# Altium schematic sheet impee-mailbox.SchDoc
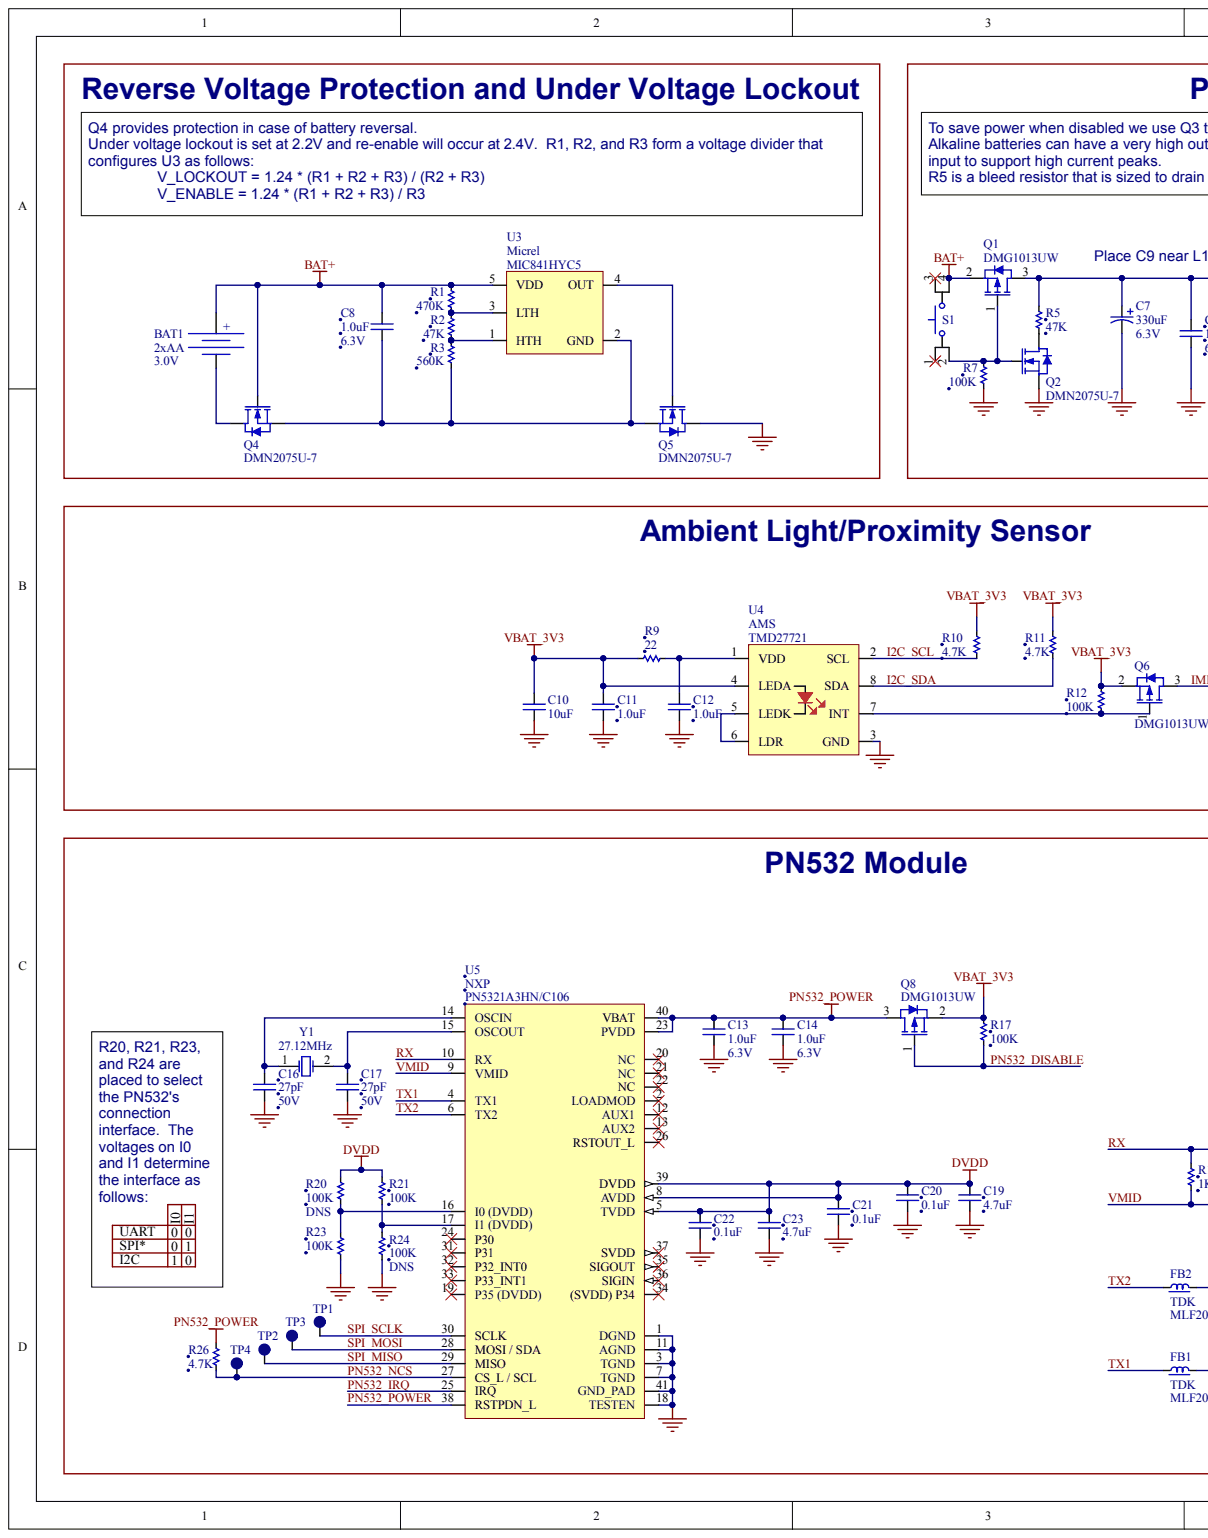
[diagram p0: impee-mailbox.SchDoc, left]
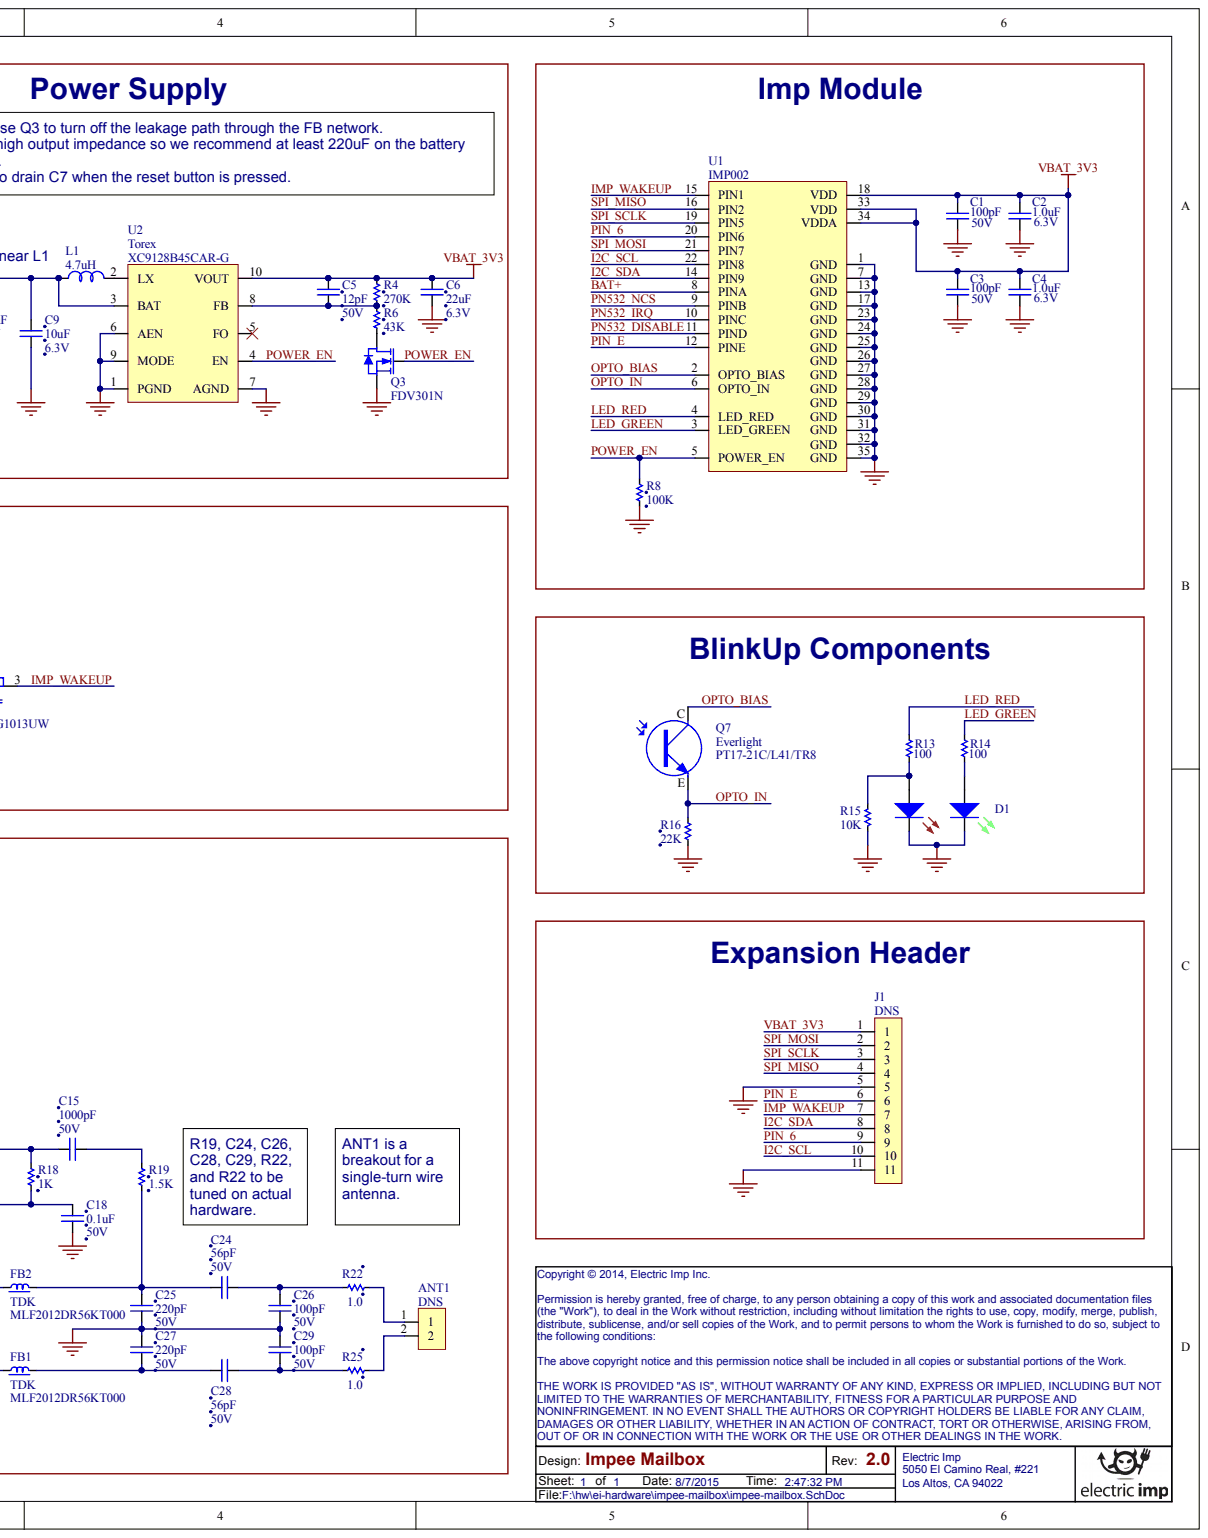
[diagram p1: impee-mailbox.SchDoc, right]

## Parts
ANT1  BOMOPTION: DNS  [HDR254P1X2-N]  (hidden comment: Header)  (no BOM)
BAT1  Configuration: 2xAA  Voltage: 3.0V  [12BH321P-GR]  (hidden comment: BATTERY)
C1  value 100pF  Voltage: 50V  [CAPC1005X07N]  (hidden comment: Ceramic Capacitor)
C2  value 1.0uF  Voltage: 6.3V  [CAPC1005X07N]  (hidden comment: Ceramic Capacitor)
C3  value 100pF  Voltage: 50V  [CAPC1005X07N]  (hidden comment: Ceramic Capacitor)
C4  value 1.0uF  Voltage: 6.3V  [CAPC1005X07N]  (hidden comment: Ceramic Capacitor)
C5  value 12pF  Voltage: 50V  [CAPC1005X07N]  (hidden comment: Ceramic Capacitor)
C6  value 22uF  Voltage: 6.3V  [CAPC2012X14N]  (hidden comment: Ceramic Capacitor)
C7  value 330uF  Voltage: 6.3V  [CAPAE8983X55N]  (hidden comment: Polarized Capacitor)
C8  value 1.0uF  Voltage: 6.3V  [CAPC1005X07N]  (hidden comment: Ceramic Capacitor)
C9  value 10uF  Voltage: 6.3V  [CAPC2012X14N]  (hidden comment: Ceramic Capacitor)
C10  value 10uF  [CAPC2012X14N]  (hidden comment: Ceramic Capacitor)
C11  value 1.0uF  [CAPC1005X07N]  (hidden comment: Ceramic Capacitor)
C12  value 1.0uF  [CAPC1005X07N]  (hidden comment: Ceramic Capacitor)
C13  value 1.0uF  Voltage: 6.3V  [CAPC1005X07N]  (hidden comment: Ceramic Capacitor)
C14  value 1.0uF  Voltage: 6.3V  [CAPC1005X07N]  (hidden comment: Ceramic Capacitor)
C15  value 1000pF  Voltage: 50V  [CAPC1005X07N]  (hidden comment: Ceramic Capacitor)
C16  value 27pF  Voltage: 50V  [CAPC1005X07N]  (hidden comment: Ceramic Capacitor)
C17  value 27pF  Voltage: 50V  [CAPC1005X07N]  (hidden comment: Ceramic Capacitor)
C18  value 0.1uF  Voltage: 50V  [CAPC2012X14N]  (hidden comment: Ceramic Capacitor)
C19  value 4.7uF  [CAPC1608X10N]  (hidden comment: Ceramic Capacitor)
C20  value 0.1uF  [CAPC1005X07N]  (hidden comment: Ceramic Capacitor)
C21  value 0.1uF  [CAPC1005X07N]  (hidden comment: Ceramic Capacitor)
C22  value 0.1uF  [CAPC1005X07N]  (hidden comment: Ceramic Capacitor)
C23  value 4.7uF  [CAPC1608X10N]  (hidden comment: Ceramic Capacitor)
C24  value 56pF  Voltage: 50V  [CAPC1005X07N]  (hidden comment: Ceramic Capacitor)
C25  value 220pF  Voltage: 50V  [CAPC1005X07N]  (hidden comment: Ceramic Capacitor)
C26  value 100pF  Voltage: 50V  [CAPC1005X07N]  (hidden comment: Ceramic Capacitor)
C27  value 220pF  Voltage: 50V  [CAPC1005X07N]  (hidden comment: Ceramic Capacitor)
C28  value 56pF  Voltage: 50V  [CAPC1005X07N]  (hidden comment: Ceramic Capacitor)
C29  value 100pF  Voltage: 50V  [CAPC1005X07N]  (hidden comment: Ceramic Capacitor)
D1  LED Red and Green  [LED1616X07N-REDGREEN]  (value not drawn)
FB1  Manufacturer: TDK  Manufacturer Part Number: MLF2012DR56KT000  [INDC2012X11N]  (hidden comment: Ferrite Bead)
FB2  Manufacturer: TDK  [INDC2012X11N]  (hidden comment: Ferrite Bead)
J1  Header  BOMOPTION: DNS  [HDR254P1X11-N]  (no BOM)
L1  value 4.7uH  [INDP6060X28N]  (hidden comment: Inductor)
Q1  Manufacturer Part Number: DMG1013UW  [SOT65P210X110-3N]  (hidden comment: PFET)
Q2  Manufacturer Part Number: DMN2075U-7  [SOT95P300X130-3N]  (hidden comment: N-Channel MOSFET)
Q3  Manufacturer Part Number: FDV301N  [SOT95P300X130-3N]  (hidden comment: N-Channel MOSFET)
Q4  Manufacturer Part Number: DMN2075U-7  [SOT95P300X130-3N]  (hidden comment: N-Channel MOSFET)
Q5  Manufacturer Part Number: DMN2075U-7  [SOT95P300X130-3N]  (hidden comment: N-Channel MOSFET)
Q6  Manufacturer Part Number: DMG1013UW  [SOT65P210X110-3N]  (hidden comment: PFET)
Q7  Manufacturer: Everlight  Manufacturer Part Number: PT17-21C/L41/TR8  [PHOTOTRANS2012X13]  (hidden comment: PHOTOTRANSISTOR)
Q8  Manufacturer Part Number: DMG1013UW  [SOT65P210X110-3N]  (hidden comment: PFET)
R1  value 470K  [RESC1005X04N]  (hidden comment: Resistor)
R2  value 47K  [RESC1005X04N]  (hidden comment: Resistor)
R3  value 560K  [RESC1005X04N]  (hidden comment: Resistor)
R4  value 270K  [RESC1005X04N]  (hidden comment: Resistor)
R5  value 47K  [RESC1005X04N]  (hidden comment: Resistor)
R6  value 43K  [RESC1005X04N]  (hidden comment: Resistor)
R7  value 100K  [RESC1005X04N]  (hidden comment: Resistor)
R8  value 100K  [RESC1005X04N]  (hidden comment: Resistor)
R9  value 22  [RESC1005X04N]  (hidden comment: Resistor)
R10  value 4.7K  [RESC1005X04N]  (hidden comment: Resistor)
R11  value 4.7K  [RESC1005X04N]  (hidden comment: Resistor)
R12  value 100K  [RESC1005X04N]  (hidden comment: Resistor)
R13  value 100  [RESC1005X04N]  (hidden comment: Resistor)
R14  value 100  [RESC1005X04N]  (hidden comment: Resistor)
R15  value 10K  [RESC1005X04N]  (hidden comment: Resistor)
R16  value 22K  [RESC1005X04N]  (hidden comment: Resistor)
R17  value 100K  [RESC1005X04N]  (hidden comment: Resistor)
R18  value 1K  [RESC1005X04N]  (hidden comment: Resistor)
R19  value 1.5K  [RESC1005X04N]  (hidden comment: Resistor)
R20  value 100K  BOMOPTION: DNS  [RESC1005X04N]  (hidden comment: Resistor)  (no BOM)
R21  value 100K  [RESC1005X04N]  (hidden comment: Resistor)
R22  value 1.0  [RESC2012X07N]  (hidden comment: Resistor)
R23  value 100K  [RESC1005X04N]  (hidden comment: Resistor)
R24  value 100K  BOMOPTION: DNS  [RESC1005X04N]  (hidden comment: Resistor)  (no BOM)
R25  value 1.0  [RESC2012X07N]  (hidden comment: Resistor)
R26  value 4.7K  [RESC1005X04N]  (hidden comment: Resistor)
S1  Push Button  [BTN600X600X430-4N]  (value not drawn)
TP1  Testpoint  [TP254P1X1-TH]  (value not drawn)  (no BOM)
TP2  Testpoint  [TP254P1X1-TH]  (value not drawn)  (no BOM)
TP3  Testpoint  [TP254P1X1-TH]  (value not drawn)  (no BOM)
TP4  Testpoint  [TP254P1X1-TH]  (value not drawn)  (no BOM)
U1  Manufacturer Part Number: IMP002  [IMP_MODULE]  (hidden comment: imp module)
U2  XC9128  Manufacturer: Torex  Manufacturer Part Number: XC9128B45CAR-G  [MSOP50P490X116-10N]
U3  MIC841  Manufacturer: Micrel  Manufacturer Part Number: MIC841HYC5  [SOT65P200X125-5N]
U4  TMD2772  Manufacturer: AMS  Manufacturer Part Number: TMD27721  [SON97P240X155-8N]
U5  PN532  Manufacturer: NXP  Manufacturer Part Number: PN5321A3HN/C106  [QFN50P600X600X100_HS-41N]
Y1  Frequency: 27.12MHz  [XTAL5032X135]  (hidden comment: XTAL)

## Nets
DVDD: C19.2 C20.2 C21.2 C22.2 C23.2 R20.1 R21.1 U5.5(TVDD) U5.8(AVDD) U5.39(DVDD)
GND: C1.1 C2.1 C3.1 C4.1 C6.1 C7.2 C9.1 C10.1 C11.1 C12.1 C13.1 C14.1 C16.1 C17.1 C18.1 C19.1 C20.1 C21.1 C22.1 C23.1 C25.1 C26.1 C27.2 C29.2 D1.KG D1.KR J1.5 J1.11 Q2.2 Q3.2 Q5.3 R7.2 R8.2 R15.1 R16.2 R23.2 R24.2 U1.1(GND) U1.7(GND) U1.13(GND) U1.17(GND) U1.23(GND) U1.24(GND) U1.25(GND) U1.26(GND) U1.27(GND) U1.28(GND) U1.29(GND) U1.30(GND) U1.31(GND) U1.32(GND) U1.35(GND) U2.1(PGND) U2.6(AEN) U2.7(AGND) U2.9(MODE) U4.3(GND) U5.1(DGND) U5.3(TGND) U5.7(TGND) U5.11(AGND) U5.18(TESTEN) U5.41(GND_PAD)
BAT+: BAT1.+ C8.2 Q1.2 Q4.1 R1.1 S1.4 U1.8(PINA) U3.5(VDD)
I2C_SCL: J1.10 R10.2 U1.22(PIN8) U4.2(SCL)
I2C_SDA: J1.8 R11.2 U1.14(PIN9) U4.8(SDA)
IMP_WAKEUP: J1.7 Q6.3 U1.15(PIN1)
LED_GREEN: R14.1 U1.3(LED_GREEN)
LED_RED: R13.1 U1.4(LED_RED)
OPTO_BIAS: Q7.C U1.2(OPTO_BIAS)
OPTO_IN: Q7.E R16.1 U1.6(OPTO_IN)
PIN_6: J1.9 U1.20(PIN6)
PIN_E: J1.6 U1.12(PINE)
PN532_DISABLE: Q8.1 R17.2 U1.11(PIND)
PN532_IRQ: U1.10(PINC) U5.25(IRQ)
PN532_NCS: R26.2 TP4.1 U1.9(PINB) U5.27(CS_L_/_SCL)
PN532_POWER: C13.2 C14.2 Q8.3 R26.1 U5.23(PVDD) U5.38(RSTPDN_L) U5.40(VBAT)
POWER_EN: Q3.1 R8.1 U1.5(POWER_EN) U2.4(EN)
RX: C15.1 R18.1 U5.10(RX)
SPI_MISO: J1.4 TP2.1 U1.16(PIN2) U5.29(MISO)
SPI_MOSI: J1.2 TP3.1 U1.21(PIN7) U5.28(MOSI_/_SDA)
SPI_SCLK: J1.3 TP1.1 U1.19(PIN5) U5.30(SCLK)
TX1: FB1.1 U5.4(TX1)
TX2: FB2.1 U5.6(TX2)
VBAT_3V3: C1.2 C2.2 C3.2 C4.2 C5.2 C6.2 C10.2 C11.2 J1.1 Q6.2 Q8.2 R4.1 R9.2 R10.1 R11.1 R12.1 R17.1 U1.18(VDD) U1.33(VDD) U1.34(VDDA) U2.10(VOUT) U4.4(LEDA)
VMID: C18.2 R18.2 U5.9(VMID)
NetANT1_1: ANT1.1 R22.2
NetANT1_2: ANT1.2 R25.2
NetBAT1_-: BAT1.- Q4.3
NetC5_1: C5.1 R4.2 R6.1 U2.8(FB)
NetC7_1: C7.1 C9.2 L1.1 Q1.3 R5.1 U2.3(BAT)
NetC8_1: C8.1 Q4.2 Q5.2 R3.2 U3.2(GND)
NetC12_2: C12.2 R9.1 U4.1(VDD)
NetC15_2: C15.2 R19.1
NetC16_2: C16.2 U5.14(OSCIN) Y1.1
NetC17_2: C17.2 U5.15(OSCOUT) Y1.2
NetC24_1: C24.1 C25.2 FB2.2 R19.2
NetC24_2: C24.2 C26.2 R22.1
NetC27_1: C27.1 C28.1 FB1.2
NetC28_2: C28.2 C29.1 R25.1
NetD1_AG: D1.AG R14.2
NetD1_AR: D1.AR R13.2 R15.2
NetL1_2: L1.2 U2.2(LX)
NetQ1_1: Q1.1 Q2.1 R7.1 S1.2
NetQ2_3: Q2.3 R5.2
NetQ3_3: Q3.3 R6.2
NetQ5_1: Q5.1 U3.4(OUT)
NetQ6_1: Q6.1 R12.2 U4.7(INT)
NetR1_2: R1.2 R2.1 U3.3(LTH)
NetR2_2: R2.2 R3.1 U3.1(HTH)
NetR20_2: R20.2 R23.1 U5.16(I0_(DVDD))
NetR21_2: R21.2 R24.1 U5.17(I1_(DVDD))
NetU4_5: U4.5(LEDK) U4.6(LDR)

Not connected: S1 1 3; U2 5(FO); U5 2(LOADMOD) 12(AUX1) 13(AUX2) 19(P35_(DVDD)) 20(NC) 21(NC) 22(NC) 24(P30) 26(RSTOUT_L) 31(P31) 32(P32_INT0) 33(P33_INT1) 34((SVDD)_P34) 35(SIGOUT) 36(SIGIN) 37(SVDD)

## Library data of the parts
ANT1 = HDR00002: HDR, 1x2 Pin, 2.54mm Pitch, Gold Flash, Vertical, TH; Manufacturer: FCI; Manufacturer Part Number: 68000-402HLF; Connector Type: Unshrouded; Database Table Name: headers$; Gender: Male; Number of Columns: 2; Contact Finish: Gold Flash; Library Name: connectors.DbLib; Manufacturer 2: 4UCON; Manufacturer Part Number 2: 00795; Mounting Type: Through Hole; Number of Positions Loaded: 2; Number of Rows: 1; Orientation: Vertical; Part Number: HDR00002; Pitch: 2.54mm; Supplier 1: Digi-Key; Supplier 2: 4UCON; Supplier Part Number 1: 609-3503-ND; Supplier Part Number 2: 00795; Supplier Price 1: 1.0506; Supplier Price 2: 0.0045
BAT1 = BAT00006: 2xAA Series Battery Clip with Through-Hole PCB Mount; Manufacturer: Eagle Plastic Devices; Database Table Name: battery$; Component Kind: Standard; ComponentLink1URL: http://www.mouser.com/ProductDetail/Eagle-Plastic-Devices/12BH321P-GR/?qs=sGAEpiMZZMt13fpse6CWDe8CZN6lv7uJ5DFrvufekr8%3d; Library Name: electromechanical.DbLib; Manufacturer Part Number: 12BH321P-GR; Part Number: BAT00006; Supplier 1: Mouser; Supplier Part Number 1: 12BH321P-GR; Supplier Price 1: 0.33
C1 C3 C26 C29 = CAPC00043: CAP,C0G,100pF,5%,50V,0402; Manufacturer: Yageo; Manufacturer Part Number: CC0402JRNPO9BN101; Database Table Name: capacitor$; Component Kind: Standard; Dielectric: C0G; Library Name: passives.DbLib; Manufacturer 2: Samsung; Manufacturer Part Number 2: CL05C101JB5NNNC; Package: 0402; Part Number: CAPC00043; Stock Date: 3/12/2013; Stock Quantity: 500; Supplier 1: Digi-Key; Supplier 2: Digi-Key; Supplier Part Number 1: 311-1024-1-ND; Supplier Part Number 2: 1276-1025-1-ND; Supplier Price 1: 0.00324; Supplier Price 2: 0.00324; Tolerance: 5%
C2 C4 C8 C11-C14 = CAPC00005: CAP,X5R,1.0uF,10%,6.3V, 0402,#; Manufacturer: Murata; Manufacturer Part Number: GRM155R60J105KE19D; Database Table Name: capacitor$; Component Kind: Standard; Dielectric: X5R; Library Name: passives.DbLib; Manufacturer 2: Samsung; Manufacturer Part Number 2: CL05A105KQ5NNNC; Part Number: CAPC00005; Stock Date: 3/12/2013; Stock Quantity: 1000; Supplier 1: Digi-Key; Supplier 2: Digi-Key; Supplier Part Number 1: 490-1320-1-ND; Supplier Part Number 2: 1276-1010-1-ND; Supplier Price 1: 0.0087; Supplier Price 2: 0.009; Tolerance: 10%
  C2 C4 C8: Package: 0402
  C11 C12: Package: 0402; Voltage: 6.3V
C5 = CAPC00041: CAP,C0G,12pF,5%,50V,0402#; Manufacturer: Yageo; Manufacturer Part Number: CC0402JRNPO9BN120; Database Table Name: capacitor$; Component Kind: Standard; Dielectric: C0G; Library Name: passives.DbLib; Manufacturer 2: Murata; Manufacturer Part Number 2: GRM1555C1H120JZ01D; Part Number: CAPC00041; Supplier 1: Digi-Key; Supplier 2: Digi-Key; Supplier Part Number 1: 311-1016-1-ND; Supplier Part Number 2: 490-1279-1-ND; Supplier Price 1: 0.00324; Supplier Price 2: 0.00525; Tolerance: 5%
C6 = CAPC00039: CAP,X5R,22uF,10%,6.3V,0805,#; Manufacturer: TDK; Manufacturer Part Number: C2012X5R0J226K/1.25; Database Table Name: capacitor$; Component Kind: Standard; Dielectric: X5R; Library Name: passives.DbLib; Manufacturer 2: Taiyo Yuden; Manufacturer Part Number 2: JMK325BJ226KM-T; Package: 0805; Part Number: CAPC00039; Stock Date: 3/12/2013; Stock Quantity: 1049; Supplier 1: Digi-Key; Supplier 2: Digi-Key; Supplier Part Number 1: 445-7679-1-ND; Supplier Part Number 2: 587-1471-2-ND; Supplier Price 1: 0.225; Supplier Price 2: 0.2288; Tolerance: 10%
C7 = CAPC00106: CAP,Alum,330uF,20%,6.3V,SMD; Manufacturer: Nichicon; Manufacturer Part Number: UWX0J331MCL1GB; Database Table Name: capacitor$; Component Kind: Standard; Dielectric: Alum; Library Name: passives.DbLib; Part Number: CAPC00106; Supplier 1: Digi-Key; Supplier Part Number 1: 493-2091-1-ND; Supplier Price 1: 0.1015; Tolerance: 20%
C9 C10 = CAPC00036: CAP,X5R,10uF,10%,6.3V,0805,#; Manufacturer: Yageo; Manufacturer Part Number: CC0805KKX5R5BB106; Database Table Name: capacitor$; Component Kind: Standard; Dielectric: X5R; Library Name: passives.DbLib; Manufacturer 2: Taiyo Yuden; Manufacturer Part Number 2: JMK212BJ106KD-T; Part Number: CAPC00036; Stock Date: 3/12/2013; Stock Quantity: 1000; Supplier 1: Digi-Key; Supplier 2: Digi-Key; Supplier Part Number 1: 311-1459-1-ND; Supplier Part Number 2: 587-1304-1-ND; Supplier Price 1: 0.03399; Supplier Price 2: 0.0408; Tolerance: 10%
  C9: Package: 0805
C15 = CAPC00092: CAP,X7R,1000pF,10%,50V,0402; Manufacturer: Samsung; Manufacturer Part Number: CL05B102KB5NCNC; Database Table Name: capacitor$; Component Kind: Standard; Dielectric: X7R; Library Name: passives.DbLib; Manufacturer 2: Yageo; Manufacturer Part Number 2: CC0402KRX7R7BB102; Package: 0402; Part Number: CAPC00092; Supplier 1: Digi-Key; Supplier 2: Digi-Key; Supplier Part Number 1: 1276-1492-1-ND; Supplier Part Number 2: 311-1352-1-ND; Supplier Price 1: 0.00279; Supplier Price 2: 0.00309; Tolerance: 10%
C16 C17 = CAPC00044: CAP,C0G,27pF,5%,50V,0402; Manufacturer: Yageo; Manufacturer Part Number: CC0402JRNPO9BN270; Database Table Name: capacitor$; Component Kind: Standard; Dielectric: C0G; Library Name: passives.DbLib; Manufacturer 2: Murata; Manufacturer Part Number 2: GRM1555C1H270JA01D; Package: 0402; Part Number: CAPC00044; Supplier 1: Digi-Key; Supplier 2: Digi-Key; Supplier Part Number 1: 311-1019-1-ND; Supplier Part Number 2: 490-5869-1-ND; Supplier Price 1: 0.00324; Supplier Price 2: 0.00525; Tolerance: 5%
C18 = CAPC00023: CAP,X7R,0.1uF,10%,50V,0805; Manufacturer: AVX; Manufacturer Part Number: 08055C104K; Database Table Name: capacitor$; Component Kind: Standard; Dielectric: X7R; Library Name: passives.DbLib; Manufacturer 2: Yageo; Manufacturer Part Number 2: CC0805KRX7R9BB104; Package: 0805; Part Number: CAPC00023; Supplier 1: Mouser; Supplier 2: Digi-Key; Supplier Part Number 1: 581-08055C104K; Supplier Part Number 2: 311-1140-1-ND; Supplier Price 1: 0.012; Supplier Price 2: 0.01257; Tolerance: 10%
C19 C23 = CAPC00033: CAP,X5R,4.7uF,10%,6.3V,0603,#; Manufacturer: Taiyo Yuden; Manufacturer Part Number: JMK107BJ475KA-T; Database Table Name: capacitor$; Component Kind: Standard; Dielectric: X5R; Library Name: passives.DbLib; Manufacturer 2: Yageo; Manufacturer Part Number 2: CC0603KRX5R5BB475; Part Number: CAPC00033; Stock Date: 3/12/2013; Stock Quantity: 1000; Supplier 1: Digi-Key; Supplier 2: Digi-Key; Supplier Part Number 1: 587-1785-1-ND; Supplier Part Number 2: 311-1521-1-ND; Supplier Price 1: 0.02475; Supplier Price 2: 0.02899; Tolerance: 10%
C20-C22 = CAPC00002: CAP,X5R,0.1uF,10%,6.3V, 0402,#; Manufacturer: TDK; Manufacturer Part Number: C1005X5R0J104K; Database Table Name: capacitor$; Component Kind: Standard; Dielectric: X5R; Library Name: passives.DbLib; Manufacturer 2: Murata; Manufacturer Part Number 2: GRM155R60J104KA01D; Part Number: CAPC00002; Stock Date: 4/19/2013; Stock Quantity: 300; Supplier 1: Digi-Key; Supplier 2: Mouser; Supplier Part Number 1: 445-1266-1-ND; Supplier Part Number 2: 81-GRM155R60J104KA1D; Supplier Price 1: 0.0066; Supplier Price 2: 0.007; Tolerance: 10%
  C20: Package: 0402; Voltage: 6.3V
C24 C28 = CAPC00045: CAP,C0G,56pF,5%,50V,0402; Manufacturer: Yageo; Manufacturer Part Number: CC0402JRNPO9BN560; Database Table Name: capacitor$; Component Kind: Standard; Dielectric: C0G; Library Name: passives.DbLib; Manufacturer 2: TDK; Manufacturer Part Number 2: C1005C0G1H560J; Package: 0402; Part Number: CAPC00045; Supplier 1: Digi-Key; Supplier 2: Digi-Key; Supplier Part Number 1: 311-1022-1-ND; Supplier Part Number 2: 445-1244-1-ND; Supplier Price 1: 0.00324; Supplier Price 2: 0.006; Tolerance: 5%
C25 C27 = CAPC00110: CAP,C0G,220pF,25%,50V,0402; Manufacturer: Samsung; Manufacturer Part Number: CL05C221JB5NNNC; Database Table Name: capacitor$; Tolerance: 5%; Component Kind: Standard; Dielectric: C0G; Library Name: passives.DbLib; Manufacturer 2: Taiyo Yuden; Manufacturer Part Number 2: UMK105CG221JV-F; Package: 0402; Part Number: CAPC00110; Supplier 1: Digi-Key; Supplier 2: Digi-Key; Supplier Part Number 1: 1276-1059-1-ND; Supplier Part Number 2: 587-1215-1-ND; Supplier Price 1: 0.0051; Supplier Price 2: 0.0051
D1 = LED00012: LED, Red and Green, 2/2V, 631/571nm, 25/35mcd, SMT; Manufacturer: Liteon; Manufacturer Part Number: LTST-C195KGJRKT; Color: Red/Green; Current - Test: 20mA; Database Table Name: LED$; Lens Type: Clear; Millicandela Rating (min): 25mcd, 35mcd; Viewing Angle: 130°; Voltage - Forward (Vf) Typ: 2V, 2V; Wavelength - Dominant: 631nm, 571nm; Wavelength - Peak: 639nm, 574nm; Component Kind: Standard; ComponentLink1URL: http://media.digikey.com/pdf/Data%20Sheets/Lite-On%20PDFs/LTST-C195KGJRKT.pdf; Library Name: opto.DbLib; Package: SMT; Part Number: LED00012; Stock Date: 8/22/2014; Stock Quantity: 31; Supplier 1: Digi-Key; Supplier Part Number 1: 160-1452-1-ND; Supplier Price 1: 0.1206
FB1 = FB00008: FERR BD, 25 Ohm @ 25Mhz, 150mA, 550 mOhm, 0805; Database Table Name: ferrite$; Component Kind: Standard; ComponentLink1URL: http://www.digikey.com/product-detail/en/MLF2012DR56KT000/445-1044-1-ND/504442; Current Rating: 150mA; DC Resistance (DCR): 550 mOhm; Impedance @ Frequency: 25 Ohm @ 25MHz; Library Name: passives.DbLib; Package: 0805; Part Number: FB00008; Supplier 1: Digi-Key; Supplier Part Number 1: 445-1044-1-ND; Supplier Price 1: 0.09568
FB2 = FB00008: FERR BD, 25 Ohm @ 25Mhz, 150mA, 550 mOhm, 0805; Manufacturer Part Number: MLF2012DR56KT000; Database Table Name: ferrite$; Component Kind: Standard; ComponentLink1URL: http://www.digikey.com/product-detail/en/MLF2012DR56KT000/445-1044-1-ND/504442; Current Rating: 150mA; DC Resistance (DCR): 550 mOhm; Impedance @ Frequency: 25 Ohm @ 25MHz; Library Name: passives.DbLib; Package: 0805; Part Number: FB00008; Supplier 1: Digi-Key; Supplier Part Number 1: 445-1044-1-ND; Supplier Price 1: 0.09568
J1 = HDR00036: HDR, 1x11 Pin, 2.54mm Pitch, Gold Flash, Vertical, TH; Manufacturer: TE Connectivity; Manufacturer Part Number: 4-644456-1; Connector Type: Unshrouded; Database Table Name: headers$; Gender: Male; Number of Columns: 11; Contact Finish: Gold Flash; Library Name: connectors.DbLib; Manufacturer 2: Molex; Manufacturer Part Number 2: 90120-0131; Mounting Type: Through Hole; Number of Positions Loaded: 11; Number of Rows: 1; Orientation: Vertical; Part Number: HDR00036; Pitch: 2.54mm; Supplier 1: Mouser; Supplier 2: Mouser; Supplier Part Number 1: 571-4-644456-1; Supplier Part Number 2: 538-90120-0131; Supplier Price 1: 0.304; Supplier Price 2: 0.72
L1 = IND00007: IND, 4.7uH, 20%, 2.7A Isat, 403 mOhm, 6028; Manufacturer: Taiyo Yuden; Manufacturer Part Number: NR6028T4R7M; Database Table Name: inductor$; Component Kind: Standard; ComponentLink1URL: http://www.yuden.co.jp/productdata/catalog/en/wound04_e.pdf; Current: 2.7A; Current - Temperature Rise: 2.7A; DC Resistance (DCR): 403 mOhm; Library Name: passives.DbLib; Package: 6028; Part Number: IND00007; Stock Date: 3/6/2013; Stock Quantity: 31; Supplier 1: Digi-Key; Supplier Part Number 1: 587-2100-1-ND; Supplier Price 1: 0.15525; Tolerance: 20%
Q1 Q6 Q8 = PFET00009: PFET, 20V, 820mA, 1V, SOT323; Manufacturer: Diodes Inc; Current - Continuous Drain (Id) @ 25° C: DMP3098L; Database Table Name: PFET$; Power - Max: 310mW; Rds On (Max) @ Id, Vgs: 750 mOhm @ 430mA, 4.5V; Vgs(th) (Max) @ Id: 1V @ 250µA; Component Kind: Standard; ComponentLink1URL: http://www.digikey.com/product-detail/en/DMG1013UW-7/DMG1013UW-7DICT-ND/2183252; Drain to Source Voltage (Vdss): 20V; Library Name: discretes.DbLib; Part Number: PFET00009; Simulation: PMOS; Supplier 1: Digi-key; Supplier Part Number 1: DMG1013UW-7DICT-ND; Supplier Price 1: 0.06575
Q2 Q4 Q5 = NFET00004: NFET, 20V, 4.2A, SOT23; Manufacturer: Diodes Incorporated; Database Table Name: NFET$; Power - Max: 800mW; Rds On (Max) @ Id, Vgs: 45 mOhm @ 3.1A, 2.5V; Vgs(th) (Max) @ Id: 1.0V @ 250uA; Component Kind: Standard; ComponentLink1URL: http://www.diodes.com/datasheets/ds31837.pdf; Current - Continuous Drain (Id) @ 25° C: 4.2A; Drain to Source Voltage (Vdss): 20V; Library Name: discretes.DbLib; Package: SOT23; Part Number: NFET00004; Stock Date: 3/5/2013; Stock Quantity: 41; Supplier 1: Digi-Key; Supplier Part Number 1: DMN2075U-7DICT-ND; Supplier Price 1: 0.08295
Q3 = NFET00003: NFET, 25V, 220mA, SOT23; Manufacturer: Fairchild; Database Table Name: NFET$; Power - Max: 350mW; Rds On (Max) @ Id, Vgs: 5 ohm @ 200mA, 2.7V; Vgs(th) (Max) @ Id: 1.06V @ 250uA; Component Kind: Standard; ComponentLink1URL: http://www.fairchildsemi.com/ds/FD/FDV301N.pdf; Current - Continuous Drain (Id) @ 25° C: 220mA; Drain to Source Voltage (Vdss): 25V; Library Name: discretes.DbLib; Package: SOT23; Part Number: NFET00003; Stock Date: 3/5/2013; Supplier 1: Digi-key; Supplier Part Number 1: FDV301NCT-ND; Supplier Price 1: 0.04155
Q7 = PHOTO00002: Phototrans, Clear, Top View, 940nm, 0.4V, 1mA, 0805; Database Table Name: Phototransistor$; Component Kind: Standard; ComponentLink1URL: http://www.digikey.com/product-detail/en/PT17-21C%2FL41%2FTR8/1080-1382-1-ND/2676116; Lens Type: Clear; Library Name: opto.DbLib; Package: 0805; Part Number: PHOTO00002; Stock Date: 10/24/2012; Stock Quantity: 7; Supplier 1: Digi-Key; Supplier Part Number 1: 1080-1382-1-ND; Supplier Price 1: 0.07101
R1 = RES00009: RES,470K,5%,0.063W,0402; Manufacturer: Yageo; Manufacturer Part Number: RC0402JR-07470KL; Database Table Name: resistor$; Component Kind: Standard; Library Name: passives.DbLib; Manufacturer 2: ROHM; Manufacturer Part Number 2: MCR01MRTJ474; Part Number: RES00009; Power: 0.063W; Supplier 1: Digi-Key; Supplier 2: Digi-Key; Supplier Part Number 1: 311-470KJRCT-ND; Supplier Part Number 2: RHM470KCECT-ND; Supplier Price 1: 0.00237; Supplier Price 2: 0.00352
R2 R5 = RES00008: RES,47K,5%,0.063W,0402; Manufacturer: Yageo; Manufacturer Part Number: RC0402JR-0747KL; Database Table Name: resistor$; Component Kind: Standard; Library Name: passives.DbLib; Manufacturer 2: ROHM; Manufacturer Part Number 2: MCR01MRTJ473; Part Number: RES00008; Power: 0.063W; Supplier 1: Digi-Key; Supplier 2: Digi-Key; Supplier Part Number 1: 311-47KJRCT-ND; Supplier Part Number 2: RHM47KCECT-ND; Supplier Price 1: 0.00237; Supplier Price 2: 0.00352
R3 = RES00054: RES,560K,1%,0.063W,0402; Manufacturer: Yageo; Manufacturer Part Number: RC0402FR-07560KL; Database Table Name: resistor$; Component Kind: Standard; Library Name: passives.DbLib; Manufacturer 2: Panasonic; Manufacturer Part Number 2: ERJ-2RKF5603X; Part Number: RES00054; Power: 0.063W; Stock Date: 4/19/2013; Stock Quantity: 100; Supplier 1: Digi-Key; Supplier 2: Digi-Key; Supplier Part Number 1: 311-560KLRCT-ND; Supplier Part Number 2: P560KLCT-ND; Supplier Price 1: 0.0027; Supplier Price 2: 0.00672
R4 = RES00015: RES,270K,5%,0.063W,0402; Manufacturer: Yageo; Manufacturer Part Number: RC0402JR-07270KL; Database Table Name: resistor$; Component Kind: Standard; Library Name: passives.DbLib; Manufacturer 2: ROHM; Manufacturer Part Number 2: MCR01MZPJ274; Package: 0402; Part Number: RES00015; Power: 0.063W; Stock Date: 4/5/2013; Stock Quantity: 6; Supplier 1: Digi-Key; Supplier 2: Digi-Key; Supplier Part Number 1: 311-270KJRDKR-ND; Supplier Part Number 2: RHM270KJCT-ND; Supplier Price 1: 0.00237; Supplier Price 2: 0.00384; Tolerance: 5%
R6 = RES00041: RES,43K,1%,0.063W,0402; Manufacturer: Yageo; Manufacturer Part Number: RC0402FR-0743KL; Database Table Name: resistor$; Component Kind: Standard; Library Name: passives.DbLib; Manufacturer 2: Rohm; Manufacturer Part Number 2: MCR01MZPF4302; Package: 0402; Part Number: RES00041; Power: 0.063W; Stock Date: 4/5/2013; Stock Quantity: 10; Supplier 1: Digi-Key; Supplier 2: Digi-Key; Supplier Part Number 1: 311-43.0KLRCT-ND; Supplier Part Number 2: RHM43.0KLCT-ND; Supplier Price 1: 0.0027; Supplier Price 2: 0.00544
R7 R8 R12 R17 R20 R21 R23 R24 = RES00001: RES,100K,5%,0.063W,0402,#; Manufacturer: Samsung; Manufacturer Part Number: RC1005J104CS; Database Table Name: resistor$; Library Name: passives.DbLib; Manufacturer 2: ROHM; Manufacturer Part Number 2: MCR01MRTJ104; Part Number: RES00001; Power: 0.063W; Stock Date: 4/5/2013; Stock Quantity: 2100; Supplier 1: Digi-Key; Supplier 2: Digi-Key; Supplier Part Number 1: 1276-4424-1-ND; Supplier Part Number 2: HM100KCECT-ND; Supplier Price 1: 0.0023; Supplier Price 2: 0.00233
  R7 R8 R12 R17: Component Kind: Standard; Package: 0402; Tolerance: 5%
  R21 R23: Component Kind: Standard
R9 = RES00060: RES,22 Ohm,5%,0.063W,0402; Manufacturer: Yageo; Manufacturer Part Number: RC0402JR-0722RL; Database Table Name: resistor$; Component Kind: Standard; Library Name: passives.DbLib; Manufacturer 2: Stackpole; Manufacturer Part Number 2: RMCF0402JT22R0; Package: 0402; Part Number: RES00060; Power: 0.063W; Supplier 1: Digi-Key; Supplier 2: Digi-Key; Supplier Part Number 1: 311-22JRCT-ND; Supplier Part Number 2: RMCF0402JT22R0CT-ND; Supplier Price 1: 0.00237; Supplier Price 2: 0.00256; Tolerance: 5%
R10 R11 R26 = RES00005: RES,4.7K,5%,0.063W,0402,#; Manufacturer: Yageo; Manufacturer Part Number: RC0402JR-074K7L; Database Table Name: resistor$; Component Kind: Standard; Library Name: passives.DbLib; Manufacturer 2: ROHM; Manufacturer Part Number 2: MCR01MRTJ472; Part Number: RES00005; Power: 0.063W; Stock Date: 4/19/2013; Stock Quantity: 100; Supplier 1: Digi-Key; Supplier 2: Digi-Key; Supplier Part Number 1: 311-4.7KJRCT-ND; Supplier Part Number 2: RHM4.7KCECT-ND; Supplier Price 1: 0.00237; Supplier Price 2: 0.00352
R13 R14 = RES00024: RES,100,1%,0.063W,0402,#; Manufacturer: Yageo; Manufacturer Part Number: RC0402FR-07100RL; Database Table Name: resistor$; Component Kind: Standard; Library Name: passives.DbLib; Manufacturer 2: ROHM; Manufacturer Part Number 2: MCR01MRTF1000; Package: 0402; Part Number: RES00024; Power: 0.063W; Stock Date: 4/5/2013; Stock Quantity: 1000; Supplier 1: Digi-Key; Supplier 2: Digi-Key; Supplier Part Number 1: 311-100LRCT-ND; Supplier Part Number 2: RHM100CDCT-ND; Supplier Price 1: 0.0027; Supplier Price 2: 0.0048; Tolerance: 1%
R15 = RES00006: RES,10k,5%,0.063W,0402,#; Manufacturer: Stackpole; Manufacturer Part Number: RMCF0402JT10K0; Database Table Name: resistor$; Component Kind: Standard; Library Name: passives.DbLib; Manufacturer 2: ROHM; Manufacturer Part Number 2: MCR01MRTJ103; Package: 0402; Part Number: RES00006; Power: 0.063W; Stock Date: 4/19/2013; Stock Quantity: 120; Supplier 1: Digi-Key; Supplier 2: Digi-Key; Supplier Part Number 1: RMCF0402JT10K0CT-ND; Supplier Part Number 2: RHM10KCECT-ND; Supplier Price 1: 0.00256; Supplier Price 2: 0.00352; Tolerance: 5%
R16 = RES00007: RES,22K,5%,0.063W,0402; Manufacturer: Yageo; Manufacturer Part Number: RC0402JR-0722KL; Database Table Name: resistor$; Component Kind: Standard; Library Name: passives.DbLib; Manufacturer 2: Panasonic; Manufacturer Part Number 2: ERJ-2GEJ223X; Part Number: RES00007; Power: 0.063W; Supplier 1: Digi-Key; Supplier 2: Digi-Key; Supplier Part Number 1: 311-22KJRCT-ND; Supplier Part Number 2: P22KJCT-ND; Supplier Price 1: 0.00237; Supplier Price 2: 0.0048
R18 = RES00003: RES,1K,5%,0.063W,0402,#; Manufacturer: Yageo; Manufacturer Part Number: RC0402JR-071KL; Database Table Name: resistor$; Component Kind: Standard; Library Name: passives.DbLib; Manufacturer 2: ROHM; Manufacturer Part Number 2: MCR01MZPJ102; Part Number: RES00003; Power: 0.063W; Stock Date: 4/19/2013; Stock Quantity: 100; Supplier 1: Digi-Key; Supplier 2: Digi-Key; Supplier Part Number 1: 311-1.0KJRCT-ND; Supplier Part Number 2: RHM1.0KJCT-ND; Supplier Price 1: 0.00237; Supplier Price 2: 0.00384
R19 = RES00100: RES,1.5K Ohm,1%,0.063W,0402; Manufacturer: Rohm; Manufacturer Part Number: MCR01MRTF1501; Database Table Name: resistor$; Component Kind: Standard; Library Name: passives.DbLib; Package: 0402; Part Number: RES00100; Power: 0.063W; Supplier 1: Digi-Key; Supplier Part Number 1: RHM1.50KCDCT-ND; Supplier Price 1: 0.00233; Tolerance: 1%
R22 R25 = RES00018: RES,1 Ohm,1%,0.25W,0805; Manufacturer: ROHM; Manufacturer Part Number: MCR10ERTFL1R00; Database Table Name: resistor$; Power: 0.125; Component Kind: Standard; Library Name: passives.DbLib; Manufacturer 2: Stackpole; Manufacturer Part Number 2: CSR0805FK1R00; Part Number: RES00018; Supplier 1: Digi-Key; Supplier 2: Digi-Key; Supplier Part Number 1: RHM1.00CICT-ND; Supplier Part Number 2: CSR0805FK1R00CT-ND; Supplier Price 1: 0.0459; Supplier Price 2: 0.074
S1 = BTN00001: SPST- NO Momentary Button, 160g Force, SMD; Manufacturer: TE Connectivity; Manufacturer Part Number: FSM4JSMA; Database Table Name: button$; Component Kind: Standard; ComponentLink1URL: http://www.digikey.com/product-detail/en/FSM4JSMATR/450-1759-1-ND/2271638; Library Name: electromechanical.DbLib; Part Number: BTN00001; Stock Date: 9/23/2014; Stock Quantity: 55; Supplier 1: Digi-Key; Supplier Part Number 1: 450-1129-ND; Supplier Price 1: 0.0917
TP1-TP4 = ELEC00022: Database Table Name: misc$; Description: Testpoint; Library Name: electrical.DbLib; Part Number: ELEC00022
U1 = MOD00002: Module, Electric Imp Solder Down with Antenna; Database Table Name: misc$; Component Kind: Standard; Library Name: modules.DbLib; Manufacturer: Electric Imp; Part Number: MOD00002; Supplier 1: Digi-Key; Supplier Part Number 1: 1413-1001-1-ND; Supplier Price 1: 24.1001
U2 = IC00026: IC, DC/DC boost,Adjustable, 6 VIN, 500mA, MSOP10; Database Table Name: misc$; Component Kind: Standard; ComponentLink1URL: http://www.torex.co.jp/english/products/dcdc_converters/data/XC9128~29.pdf; Library Name: ic.DbLib; Manufacturer 2: Torex; Manufacturer Part Number 2: XC9128D45CAR-G; Part Number: IC00026; Stock Date: 2/27/2013; Stock Quantity: 8; Supplier 1: Digi-Key; Supplier Part Number 1: 893-1104-1-ND; Supplier Price 1: 0.882
U3 = IC00032: IC, Comparator with Reference and Programmable Hysteresis, SOT-353; Database Table Name: misc$; Component Kind: Standard; ComponentLink1URL: http://www.micrel.com/_PDF/mic841.pdf; Library Name: ic.DbLib; Part Number: IC00032; Stock Date: 2/27/2013; Stock Quantity: 1; Supplier 1: Digi-Key; Supplier Part Number 1: 576-2923-1-ND; Supplier Price 1: 0.504
U4 = IC00196: IC, Sensor, ALS and Proximity, I2C; Database Table Name: misc$; Component Kind: Standard; ComponentLink1URL: http://ams.com/eng/Products/Light-Sensors/Ambient-Light-Sensor-Proximity-Detection/TMD27721; Library Name: ic.DbLib; Part Number: IC00196; Supplier 1: Digi-Key; Supplier Part Number 1: TMD27721CT-N; Supplier Price 1: 1.3248
U5 = IC00195: IC, NFC Reader, QFN40; Database Table Name: misc$; Component Kind: Standard; ComponentLink1URL: http://www.digikey.com/product-detail/en/PN5321A3HN%2FC106%3B55/568-8600-ND/2296486; Library Name: ic.DbLib; Part Number: IC00195; Supplier 1: Digi-Key; Supplier Part Number 1: 568-8600-ND; Supplier Price 1: 5.03119
Y1 = XTAL00003: XTAL, 27.12MHz, 30ppm, 18pF, 50 Ohm, 5032; Manufacturer: TXC; Manufacturer Part Number: 7A-27.120MAAJ-T; Database Table Name: xtal$; Operating Mode: Fundamental; Component Kind: Standard; ComponentLink1URL: http://www.digikey.com/product-detail/en/7A-27.120MAAJ-T/887-1419-1-ND/2208001; Frequency Stability: 30ppm; Frequency Tolerance: 30ppm; Load Capacitance: 18pF; Package: 2-SMD; Part Number: XTAL00003; Stock Date: 8/7/2013; Stock Quantity: 6; Supplier 1: Digi-Key; Supplier Part Number 1: 887-1419-2-ND; Supplier Price 1: 0.35; Library Name: xtalosc.DbLib
